# Altium schematic sheet Clocking.SchDoc(Clocking)
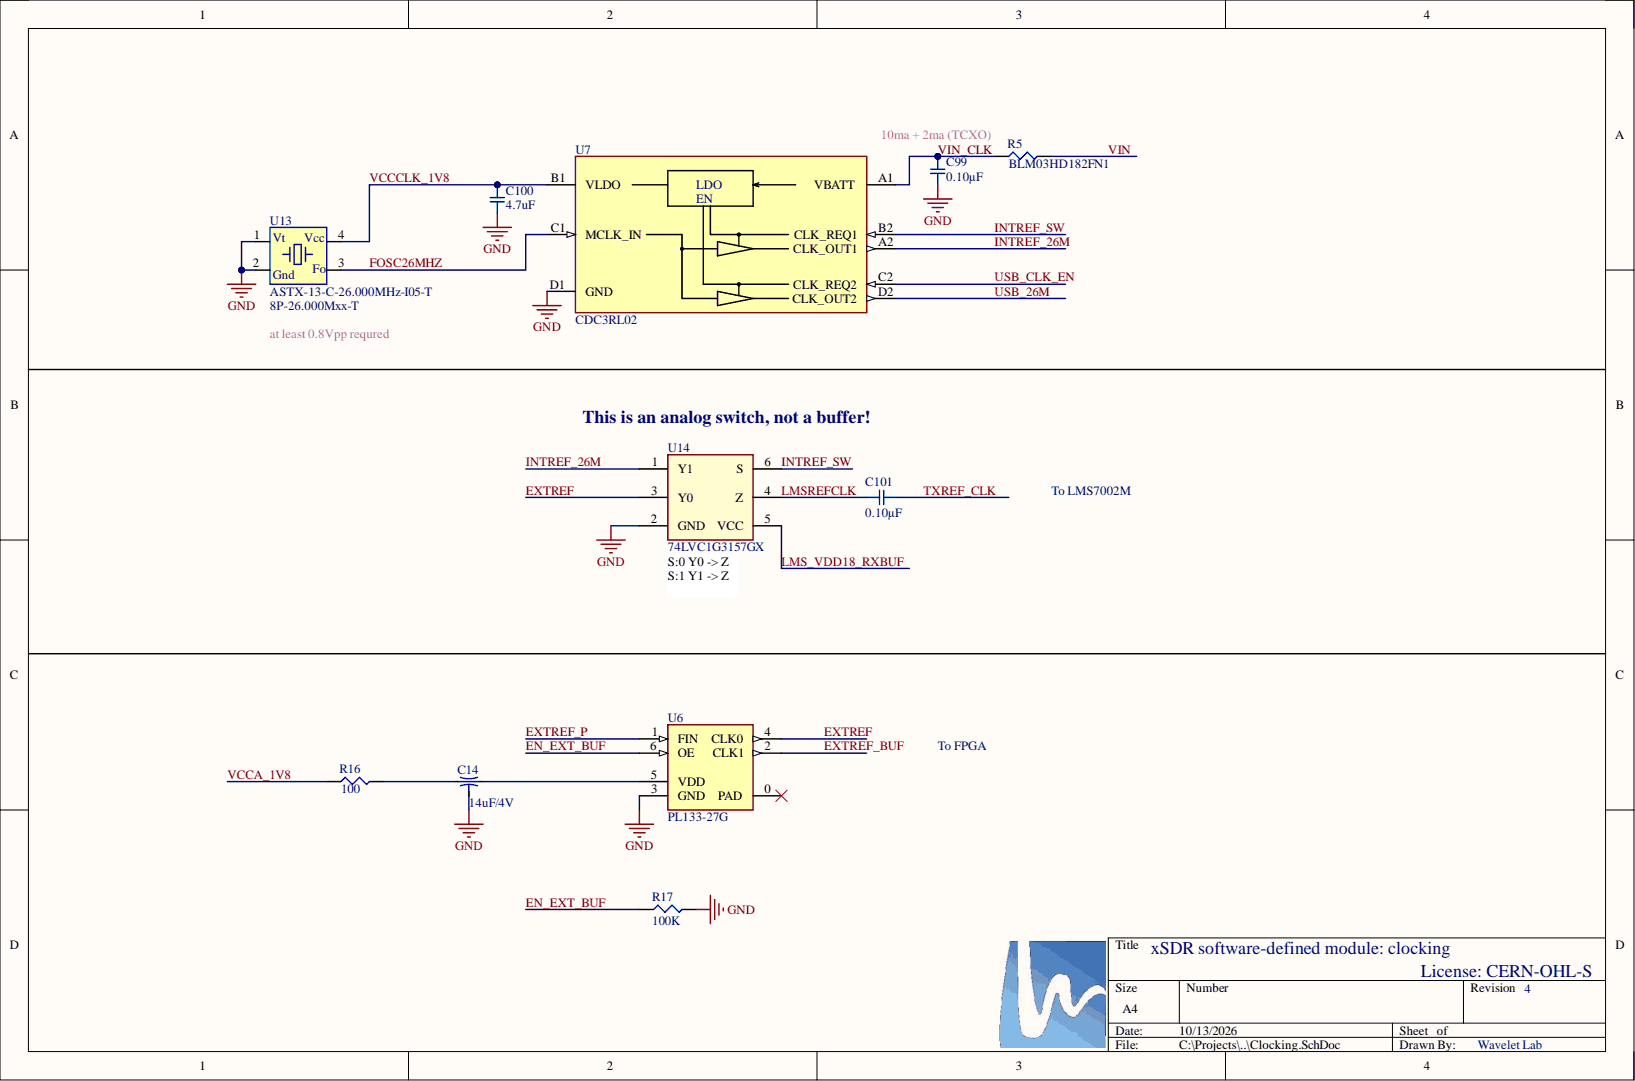
[diagram p0: Clocking.SchDoc]

## Parts
C14  14uF/4V  [NFM15]
C99  value 0.10µF  [SMD-0201C]
C100  value 4.7uF  [SMD-0201C]
C101  value 0.10µF  [SMD-0201C]
R5  value BLM03HD182FN1  [SMD-0201-RES]
R16  value 100  [SMD-0201-RES]
R17  100K  [SMD-0201-RES]
U6  PL133-27G  [TDFN-6 -2013]
U7  CDC3RL02  [BGA 8DSBGA]
U13  ASTX-13-C-26.000MHz-I05-T  Analog: 8P-26.000Mxx-T  [TCXO1612_2016_COMBINED]
U14  74LVC1G3157GX  [SOT1255]

## Nets
GND: C14.2 C99.2 C100.2 R17.2 U6.3(GND) U7.D1(GND) U13.1(Vt) U13.2 U14.2(GND)
EN_EXT_BUF: R17.1 U6.6(OE)
EXTREF: U6.4(CLK0) U14.3(Y0)
EXTREF_BUF: U6.2(CLK1)
EXTREF_P: U6.1(FIN)
FOSC26MHZ: U7.C1(MCLK_IN) U13.3(Fo)
INTREF_26M: U7.A2(CLK_OUT1) U14.1(Y1)
INTREF_SW: U7.B2(CLK_REQ1) U14.6(S)
LMS_VDD18_RXBUF: U14.5(VCC)
LMSREFCLK: C101.1 U14.4(Z)
TXREF_CLK: C101.2
USB_26M: U7.D2(CLK_OUT2)
USB_CLK_EN: U7.C2(CLK_REQ2)
VCCA_1V8: R16.1
VCCCLK_1V8: C100.1 U7.B1(VLDO) U13.4(Vcc)
VIN: R5.2
VIN_CLK: C99.1 R5.1 U7.A1(VBATT)
NetC14_1: C14.1 R16.2
NetC14_3: C14.3 U6.5(VDD)

Not connected: U6 0(PAD)

## Library data of the parts
C14 = NFM15: Filter EMI 0402 Feedthrough; Manufacturer: MuRata; Name: NFM15PC146R0G3D; Tolerance: 20%; U/P/TKE: 4V/2A/30mO/-55+85℃; Component Kind: Standard; Link: https://octopart.com/nfm15pc146r0g3d-murata-94414995; PDF: https://datasheet.octopart.com/NFM15PC146R0G3D-Murata-datasheet-138760002.pdf; Value: 14uF/4V; Library Name: USDR.IntLib
C99-C101 = GRM033R60J104ME19D: CAP CER 0.1UF 6.3V X5R 0201; Manufacturer: Murata Electronics North America; @ qty: 0; Minimum Quantity: 1; Tolerance: ±20%; Unit Price (USD): 0.1; Applications: General Purpose; Component Kind: Standard; Datasheets: http://www.murata.com/~/media/webrenewal/support/library/catalog/products/capacitor/mlcc/c02e.pdf; Digi-Key Part Number: 490-5404-1-ND; Factory Stock: 0; Failure Rate: Surface Mount, MLCC; Features: -; Height - Seated (Max): 0.013" (0.33mm); Image: //media.digikey.com/Renders/Murata%20Renders/ZRB-SERIES-0402.jpg; Lead Spacing: -; Mounting Type: 0201 (0603 Metric); Operating Temperature: -55°C ~ 85°C; Package / Case: 0.024" L x 0.012" W (0.60mm x 0.30mm); Packaging: Cut Tape (CT); Part Number: GRM033R60J104ME19D; Part Status: Active; Quantity Available: 381529; Ratings: -; Series: GRM; Size / Dimension: -; Temperature Coefficient: X5R; Thickness (Max): -; Voltage: 6.3V; Library Name: USDR.IntLib
R5 = ERJ-1GE0R00C: RES SMD 0.0OHM JUMPER 1/20W 0201; Manufacturer: Panasonic Electronic Components; @ qty: 1500; Factory Stock: 0; Minimum Quantity: 1; Power (Watts): 0.05W, 1/20W; Unit Price (USD): 0.00960; Component Kind: Standard; Composition: Thick Film; Datasheets: //media.digikey.com/pdf/Data%20Sheets/Panasonic%20Resistors%20Thermistors%20PDFs/ERJ_G_Rev06.pdf; Digi-Key Part Number: P0.0AGCT-ND; Failure Rate: -; Features: -; Height - Seated (Max): 0.010" (0.26mm); Image: //media.digikey.com/Renders/Panasonic%20Renders/ERJ-0201%20Pkg.jpg; Number of Terminations: 2; Operating Temperature: -55°C ~ 125°C; Package / Case: 0201 (0603 Metric); Packaging: Cut Tape (CT); Part Number: ERJ-1GE0R00C; Part Status: Active; Quantity Available: 2883022; Series: ERJ; Size / Dimension: 0.024" L x 0.012" W (0.60mm x 0.30mm); Supplier Device Package: 0201; Temperature Coefficient: -; Tolerance: Jumper; Library Name: USDR.IntLib
R16 = ERJ-1GEJ101C: RES SMD 100 OHM 5% 1/20W 0201; Manufacturer: Panasonic Electronic Components; @ qty: 1500; Factory Stock: 0; Minimum Quantity: 1; Power (Watts): 0.05W, 1/20W; Temperature Coefficient: ±200ppm/°C; Unit Price (USD): 0.00787; Component Kind: Standard; Composition: Thick Film; Datasheets: //media.digikey.com/pdf/Data%20Sheets/Panasonic%20Resistors%20Thermistors%20PDFs/ERJ%205%25.pdf; Digi-Key Part Number: P100AGCT-ND; Failure Rate: -; Features: -; Height - Seated (Max): 0.010" (0.26mm); Image: //media.digikey.com/Renders/Panasonic%20Renders/ERJ-0201%20Pkg.jpg; Number of Terminations: 2; Operating Temperature: -55°C ~ 125°C; Package / Case: 0201 (0603 Metric); Packaging: Cut Tape (CT); Part Number: ERJ-1GEJ101C; Part Status: Active; Quantity Available: 115724; Series: ERJ; Size / Dimension: 0.024" L x 0.012" W (0.60mm x 0.30mm); Supplier Device Package: 0201; Tolerance: ±5%; Library Name: USDR.IntLib
R17 = ERJ-1GEJ103C: RES SMD 100K 5% 1/20W 0201; Manufacturer: Panasonic Electronic Components; Part Number: ERJ-1GEJ104C; @ qty: 1500; Factory Stock: 0; Minimum Quantity: 1; Power (Watts): 0.05W, 1/20W; Temperature Coefficient: ±200ppm/°C; Unit Price (USD): 0.00787; Component Kind: Standard; Composition: Thick Film; Datasheets: //media.digikey.com/pdf/Data%20Sheets/Panasonic%20Resistors%20Thermistors%20PDFs/ERJ%205%25.pdf; Digi-Key Part Number: P10KAGCT-ND; Failure Rate: -; Features: -; Height - Seated (Max): 0.010" (0.26mm); Image: //media.digikey.com/Renders/Panasonic%20Renders/ERJ-0201%20Pkg.jpg; Library Name: Resistors.IntLib; Number of Terminations: 2; Operating Temperature: -55°C ~ 125°C; Package / Case: 0201 (0603 Metric); Packaging: Cut Tape (CT); Part Status: Active; Quantity Available: 115724; Series: ERJ; Size / Dimension: 0.024" L x 0.012" W (0.60mm x 0.30mm); Supplier Device Package: 0201; Tolerance: ±5%; Value: 100K
U6 = PL133-27: IC, 1.62V to 3.63V, 1MHz To 150MHz, 1:2 Fanout Buffer; Manufacturer: Nexperia; Component Kind: Standard; ComponentLink1Description: Link; ComponentLink1URL: https://octopart.com/search?q=TPS7A3501DRV&currency=USD&specs=0&in_stock_only=1; Library Name: IC Digital.IntLib; Name: PL133-27G; PDF: https://assets.nexperia.com/documents/data-sheet/74LVC1G3157.pdf
U7 = CDC3RL02: IC, Low Phase-Noise Two-Channel Clock Fan-Out Buffer; Manufacturer: TI; U/P/TKE: 1.7-2.0V/-40+85C; Component Kind: Standard; Name: CDC3RL02; PDF: https://www.ti.com/lit/ds/symlink/cdc3rl02.pdf; Library Name: USDR.IntLib
U13 = ASVTX_13: TCVCXO; Analog Manufacturer: TXC Corporation; Manufacturer: Abracon; Misc: TCXO; Analog PDF: http://www.txccrystal.com/images/pdf/8p.pdf; Component Kind: Standard; ComponentLink1Description: Link; ComponentLink1URL: https://octopart.com/search?q=ASTX-13-C-26.000MHz-I05-T&currency=USD&specs=0; Name: ASTX-13-C-26.000MHz-I05-T; Library Name: USDR.SchLib
U14 = 74LVC1G3157: IC 2-channel multiplexer\demultiplexer; Manufacturer: Nexperia; Component Kind: Standard; ComponentLink1Description: Link; ComponentLink1URL: https://octopart.com/search?q=TPS7A3501DRV&currency=USD&specs=0&in_stock_only=1; Name: 74LVC1G3157GX; PDF: https://assets.nexperia.com/documents/data-sheet/74LVC1G3157.pdf; Library Name: USDR.IntLib
